# Altium schematic sheet USB Hub.SchDoc
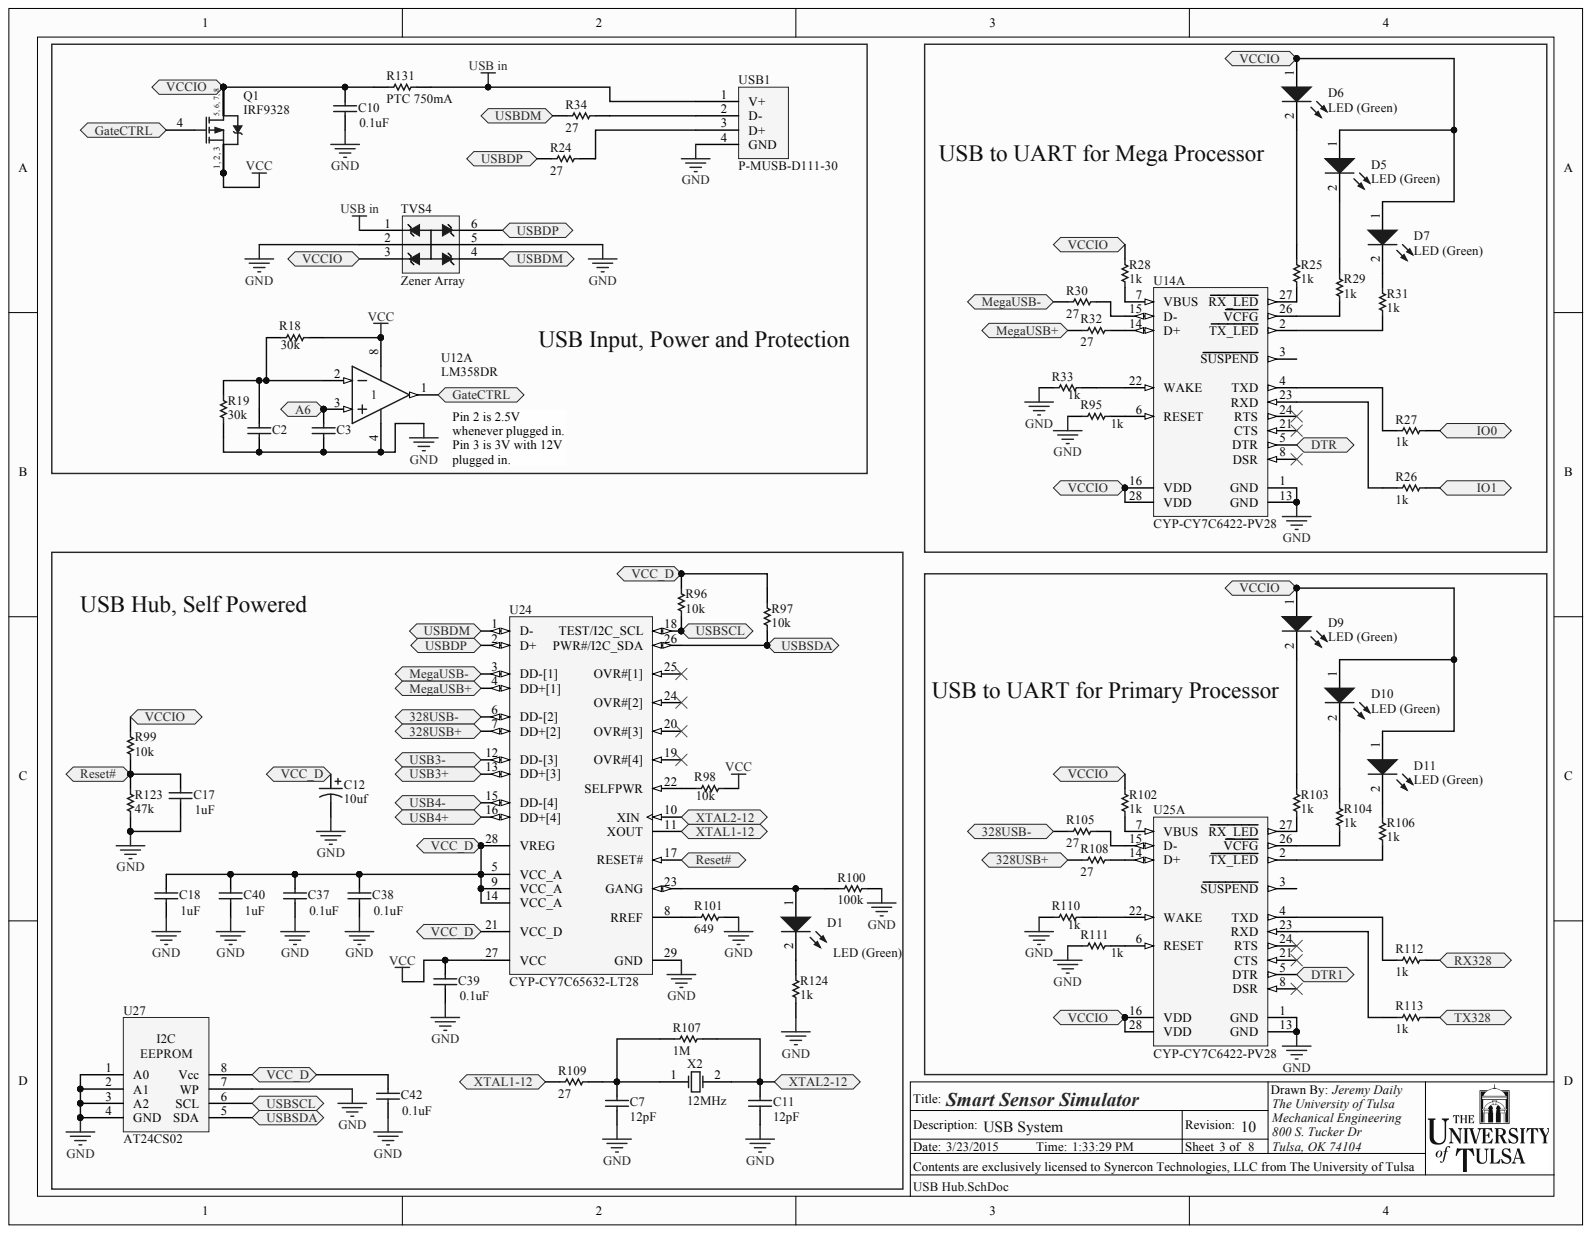
[diagram p0: USB Hub.SchDoc]

## Parts
C2  0.1uF  [0603]  (value not drawn)
C3  0.1uF  [0603]  (value not drawn)
C7  12pF  [0603]
C10  0.1uF  [0603]
C11  12pF  [0603]
C12  10uf  [Elec. Cap - C]
C17  1uF  [0603]
C18  1uF  [0603]
C37  0.1uF  [0603]
C38  0.1uF  [0603]
C39  0.1uF  [0603]
C40  1uF  [0603]
C42  0.1uF  [0603]
D1  LED (Green)  [0805 Polarized]
D5  LED (Green)  [0805 Polarized]
D6  LED (Green)  [0805 Polarized]
D7  LED (Green)  [0805 Polarized]
D9  LED (Green)  [0805 Polarized]
D10  LED (Green)  [0805 Polarized]
D11  LED (Green)  [0805 Polarized]
Q1  IRF9328  [SO-8_L]
R18  30k  [0805]
R19  30k  [0805]
R24  27  [0805]
R25  1k  [0603]
R26  1k  [0603]
R27  1k  [0603]
R28  1k  [0603]
R29  1k  [0603]
R30  27  [0805]
R31  1k  [0603]
R32  27  [0805]
R33  1k  [0603]
R34  27  [0805]
R95  1k  [0603]
R96  10k  [0805]
R97  10k  [0805]
R98  10k  [0805]
R99  10k  [0805]
R100  100k  [0603]
R101  649  [0603]
R102  1k  [0603]
R103  1k  [0603]
R104  1k  [0603]
R105  27  [0805]
R106  1k  [0603]
R107  1M  [0603]
R108  27  [0805]
R109  27  [0805]
R110  1k  [0603]
R111  1k  [0603]
R112  1k  [0603]
R113  1k  [0603]
R123  47k  [0603]
R124  1k  [0603]
R131  PTC 750mA  [1206]
TVS4  Zener Array  [SOT23-6]
U12  LM358DR  [SOIC-8]  (part A)
U14  CYP-CY7C6422-PV28  [CYP-PVX28_M]  (part A)
U24  CYP-CY7C65632-LT28  [CYP-LTX28_L]
U25  CYP-CY7C6422-PV28  [CYP-PVX28_M]  (part A)
U27  AT24CS02  [SOIC-8]
USB1  P-MUSB-D111-30  [MUSB]
X2  12MHz  [XTAL 2 pad]

## Ports
328USB+, 328USB-, A6, DTR, DTR1, GATECTRL, IO0, IO1, MEGAUSB+, MEGAUSB-, RESET#, RX328, TX328, USB3+, USB3-, USB4+, USB4-, USBDM, USBDP, USBSCL, USBSDA, VCC_D, VCCIO, XTAL1-12, XTAL2-12

## Nets
GND: C2.2 C3.2 C7.1 C10.2 C11.1 C12.2 C17.2 C18.2 C37.2 C38.2 C39.2 C40.2 C42.2 R19.2 R33.2 R95.2 R100.2 R101.1 R110.2 R111.2 R123.1 R124.2 TVS4.2 TVS4.5 U12.4 U14.1(GND) U14.13(GND) U24.29(GND) U25.1(GND) U25.13(GND) U27.1(A0) U27.2(A1) U27.3(A2) U27.4(GND) U27.7(WP) USB1.4(GND)
USB IN: R131.2 TVS4.1 USB1.1(V+)
VCC: C39.1 Q1.1 Q1.2 Q1.3 R18.1 R98.1 U12.8 U24.27(VCC)
A6: C3.1 U12.3 ; port A6
DTR: U14.5(DTR) ; port DTR
DTR1: U25.5(DTR) ; port DTR1
IO0: R27.1 ; port IO0
IO1: R26.1 ; port IO1
RX328: R112.1 ; port RX328
TX328: R113.1 ; port TX328
USBDM: R34.2 TVS4.4 U24.1(D-) ; port USBDM
USBDP: R24.2 TVS4.6 U24.2(D+) ; port USBDP
USBSCL: R96.2 U24.18(TEST/I2C_SCL) U27.6(SCL) ; port USBSCL
USBSDA: R97.2 U24.26(PWR#/I2C_SDA) U27.5(SDA) ; port USBSDA
VCC_D: C12.1 C18.1 C37.1 C38.1 C40.1 C42.1 R96.1 R97.1 U24.5(VCC_A) U24.9(VCC_A) U24.14(VCC_A) U24.21(VCC_D) U24.28(VREG) U27.8(Vcc) ; port VCC_D
VCCIO: C10.1 D5.1 D6.1 D7.1 D9.1 D10.1 D11.1 Q1.5 Q1.6 Q1.7 Q1.8 R28.1 R99.1 R102.1 R131.1 TVS4.3 U14.16(VDD) U14.28(VDD) U25.16(VDD) U25.28(VDD) ; port VCCIO
XTAL1-12: R109.2 U24.11(XOUT) ; port XTAL1-12
XTAL2-12: C11.2 R107.1 U24.10(XIN) X2.2 ; port XTAL2-12
NetC2_1: C2.1 R18.2 R19.1 U12.2
NetC7_2: C7.2 R107.2 R109.1 X2.1
NetC17_1: C17.1 R99.2 R123.2 U24.17(RESET#) ; port RESET#
NetD1_1: D1.1 R100.1 U24.23(GANG)
NetD1_2: D1.2 R124.1
NetD5_2: D5.2 R29.1
NetD6_2: D6.2 R25.1
NetD7_2: D7.2 R31.1
NetD9_2: D9.2 R103.1
NetD10_2: D10.2 R104.1
NetD11_2: D11.2 R106.1
NetQ1_4: Q1.4 U12.1 ; port GATECTRL
NetR24_1: R24.1 USB1.3(D+)
NetR25_2: R25.2 U14.27(RX_LED)
NetR26_2: R26.2 U14.23(RXD)
NetR27_2: R27.2 U14.4(TXD)
NetR28_2: R28.2 U14.7(VBUS)
NetR29_2: R29.2 U14.26(VCFG)
NetR30_1: R30.1 U14.15(D-)
NetR30_2: R30.2 U24.3(DD-[1])
NetR31_2: R31.2 U14.2(TX_LED)
NetR32_1: R32.1 U14.14(D+)
NetR32_2: R32.2 U24.4(DD+[1])
NetR33_1: R33.1 U14.22(WAKE)
NetR34_1: R34.1 USB1.2(D-)
NetR95_1: R95.1 U14.6(RESET)
NetR98_2: R98.2 U24.22(SELFPWR)
NetR101_2: R101.2 U24.8(RREF)
NetR102_2: R102.2 U25.7(VBUS)
NetR103_2: R103.2 U25.27(RX_LED)
NetR104_2: R104.2 U25.26(VCFG)
NetR105_1: R105.1 U25.15(D-)
NetR105_2: R105.2 U24.6(DD-[2])
NetR106_2: R106.2 U25.2(TX_LED)
NetR108_1: R108.1 U25.14(D+)
NetR108_2: R108.2 U24.7(DD+[2])
NetR110_1: R110.1 U25.22(WAKE)
NetR111_1: R111.1 U25.6(RESET)
NetR112_2: R112.2 U25.4(TXD)
NetR113_2: R113.2 U25.23(RXD)
NetU24_12: U24.12(DD-[3])
NetU24_13: U24.13(DD+[3])
NetU24_15: U24.15(DD-[4])
NetU24_16: U24.16(DD+[4])

Not connected: U14 3(SUSPEND) 8(DSR) 21(CTS) 24(RTS); U24 19(OVR#[4]) 20(OVR#[3]) 24(OVR#[2]) 25(OVR#[1]); U25 3(SUSPEND) 8(DSR) 21(CTS) 24(RTS)

## Library data of the parts
C2 C3 C10 C37-C39 C42 = Cap: CAP CER 0.1UF 50V 10% X7R 0603; Manufacturer: Murata Electronics North America; PartNumber: GRM188R71H104KA93D; Component Kind: Standard; Library Name: SSS Schematic Library.SchLib; Signal Integrity: Cap; Simulation: CAP; Supplier 1: Digi-Key; Supplier Part Number 1: 490-1519-1-ND
C7 C11 = Cap: CAP CER 12PF 50V 5% NP0 0603; Manufacturer: Kemet; PartNumber: C0603C120J5GACTU; Component Kind: Standard; Library Name: SSS Schematic Library.SchLib; Signal Integrity: Cap; Simulation: CAP; Supplier 1: Digi-Key; Supplier Part Number 1: 399-1050-1-ND
C12 = SMD Elec: CAP ALUM 10UF 35V 20% SMD; Manufacturer: Panasonic Electronic Components; PartNumber: EEE-HB1V100R; Component Kind: Standard; Library Name: SSS Schematic Library.SchLib; Supplier 1: Digi-Key; Supplier Part Number 1: PCE4153CT-ND
C17 C18 C40 = Cap: CAP CER 1UF 25V 10% X5R 0603; Manufacturer: Murata Electronics North America; PartNumber: GRM188R61E105KA12D; Component Kind: Standard; Library Name: SSS Schematic Library.SchLib; Signal Integrity: Cap; Simulation: CAP; Supplier 1: Digi-Key; Supplier Part Number 1: 490-3897-1-ND
D1 D5-D7 D9-D11 = SMT LED: LED CHIPLED 570NM GREEN 0805 SMD; Manufacturer: OSRAM Opto Semiconductors Inc; PartNumber: LG R971-KN-1; Component Kind: Standard; Library Name: SSS Schematic Library.SchLib; Supplier 1: Digi-Key; Supplier Part Number 1: 475-1410-1-ND
Q1 = IRF9328 P-FET: MOSFET P-CH 30V 12A 8-SOIC; Manufacturer: International Rectifier; PartNumber: IRF9328PBF; Component Kind: Standard; Library Name: SSS Schematic Library.SchLib; Supplier 1: Digi-Key; Supplier Part Number 1: IRF9328PBF-ND
R18 R19 = Chip Resistor: RES SMD 30K OHM 5% 1/8W 0805; Manufacturer: Samsung Electro-Mechanics America, Inc; PartNumber: RC2012J303CS; Component Kind: Standard; Library Name: SSS Schematic Library.SchLib; Supplier 1: Digi-Key; Supplier Part Number 1: 1276-5563-1-ND
R24 R30 R32 R34 R105 R108 R109 = Chip Resistor: RES SMD 27 OHM 1% 1/8W 0805; Manufacturer: Panasonic Electronic Components; PartNumber: ERJ-6ENF27R0V; Component Kind: Standard; Library Name: SSS Schematic Library.SchLib; Supplier 1: Digi-Key; Supplier Part Number 1: P27.0CCT-ND
R25-R29 R31 R33 R95 R102-R104 R106 R110-R113 R124 = SMD Resistor: RES SMD 1K OHM 1% 1/10W 0603; Manufacturer: Panasonic Electronic Components; PartNumber: ERJ-3EKF1001V; Component Kind: Standard; Library Name: SSS Schematic Library.SchLib; Supplier 1: Digi-Key; Supplier Part Number 1: P1.00KHCT-ND
R96-R99 = Chip Resistor: RES SMD 10K OHM 1% 1/8W 0805; Manufacturer: Panasonic Electronic Components; PartNumber: ERJ-6ENF1002V; Component Kind: Standard; Library Name: SSS Schematic Library.SchLib; Supplier 1: Digi-Key; Supplier Part Number 1: P10.0KCCT-ND
R100 = Chip Resistor: RES SMD 100K OHM 5% 1/10W 0603; Manufacturer: Panasonic Electronic Components; PartNumber: ERJ-3GEYJ104V; Component Kind: Standard; Library Name: SSS Schematic Library.SchLib; Supplier 1: Digi-Key; Supplier Part Number 1: P100KGCT-ND
R101 = Chip Resistor: RES SMD 649 OHM 1% 1/10W 0603; Manufacturer: Panasonic Electronic Components; PartNumber: ERJ-3EKF6490V; Component Kind: Standard; Library Name: SSS Schematic Library.SchLib; Supplier 1: Digi-Key; Supplier Part Number 1: P649HCT-ND
R107 = Chip Resistor: RES SMD 1M OHM 1% 1/10W 0603; Manufacturer: Panasonic Electronic Components; PartNumber: ERJ-3EKF1004V; Component Kind: Standard; Library Name: SSS Schematic Library.SchLib; Supplier 1: Digi-Key; Supplier Part Number 1: P1.00MHCT-ND
R123 = Chip Resistor: RES SMD 47K OHM 5% 1/10W 0603; Manufacturer: Vishay Dale; PartNumber: CRCW060347K0JNEA; Component Kind: Standard; Library Name: SSS Schematic Library.SchLib; Supplier 1: Digi-Key; Supplier Part Number 1: 541-47KGCT-ND
R131 = SMD Resistor: PTC RESTTBLE 0.75A 16V CHIP 1206; Manufacturer: Bel Fuse Inc; PartNumber: 0ZCJ0075AF2E; Component Kind: Standard; Library Name: SSS Schematic Library.SchLib; Supplier 1: Digi-Key; Supplier Part Number 1: 507-1805-1-ND
TVS4 = TVS Diode Array: TVS DIODE 5VWM 6.8VC SOT23; Manufacturer: Wurth Electronics Inc; PartNumber: 82402304; Component Kind: Standard; Library Name: SSS Schematic Library.SchLib; Supplier 1: Digi-Key; Supplier Part Number 1: 732-4476-1-ND
U12 = LM358DR: IC OPAMP GP 700KHZ 8SOIC; Manufacturer: Texas Instruments; Code_IPC: SOIC127P600-8; Component Kind: Standard; ComponentLink2URL: http://www-s.ti.com/sc/ds/lm358.pdf; DatasheetVersion: Aug-1988; Library Name: TI Operational Amplifier.IntLib; PackageDescription: 8-Pin Small Outline Integrated Circuit 1.27 mm Pitch; PackageVersion: Jan-1998; PartNumber: LM358DR; PCB3D: D008; Supplier 1: Digi-Key; Supplier Part Number 1: 296-1014-1-ND
U14 U25 = CMP-0967-00141-1: IC USB TO UART BRIDGE 28SSOP; Manufacturer: Cypress; PartNumber: CY7C64225-28PVXC; Component Kind: Standard; Supplier 1: Digi-Key; Supplier Part Number 1: 428-3158-ND
U24 = CY7C65632-28LTXC: HX2VL(TM) Very Low Power USB 2.0 Hub Controller, 4 Hub Ports, 0 to 70 degC, 28-Pin QFN (LTX28), Bulk; Manufacturer: Cypress; Max. Operating Voltage (V): 5.25; Min. Operating Voltage (V): 3.15; No. of Hub Ports: 4; Single/Multi TT: Single; Component Kind: Standard; ComponentLink1Description: Manufacturer URL; ComponentLink2URL: http://www.cypress.com/?docID=39996; ComponentLink3Description: Package Specification; ComponentLink3URL: http://www.cypress.com/?docID=33735; DatasheetVersion: Rev. *F; Library Name: Cypress USB Controllers.IntLib; Max. Operating Temp. (degreesC): 70; Min. Operating Temp. (degreesC): 0; Mounting Technology: Surface Mount; PackageDescription: 28-Pin Quad Flat No-Lead Package, Body 5 x 5 mm, Pitch 0.5 mm; PackageVersion: Rev. **, 10/2010; Packing: Bulk; PartNumber: CY7C65632-28LTXC; RoHS: Pb-Free; Supplier 1: Digi-Key; Supplier 2: Newark; Supplier 3: Mouser; Supplier Part Number 1: CY7C65632-28LTXC-ND; Supplier Part Number 2: 66T6131; Supplier Part Number 3: 727-CY7C65632-28LTXC
U27 = I2C EEPROM: Manufacturer: Atmel; PartNumber: AT24CS02-SSHM-T; Component Kind: Standard; Description: i2C EEPROM; Library Name: SSS Schematic Library.SchLib; Supplier 1: Digi-Key; Supplier Part Number 1: AT24CS02-SSHM-TCT-ND
USB1 = USB-Rugged: CONN RUGGED USB RCPT R/A TYPE B; Manufacturer: Amphenol Commercial Products; Component Kind: Standard; Library Name: SSS Schematic Library.SchLib; PartNumber: MUSB-D111-30; Supplier 1: Digi-Key; Supplier Part Number 1: MUSBD11130-ND
X2 = Crystal Oscillator: CRYSTAL 12MHZ 12PF SMD; Manufacturer: TXC CORPORATION; PartNumber: 7A-12.000MAHE-T; Component Kind: Standard; Library Name: SSS Schematic Library.SchLib; Supplier 1: Digi-Key; Supplier Part Number 1: 887-1758-1-ND
